# Altium schematic sheet Rev_C_Mcu.SchDoc
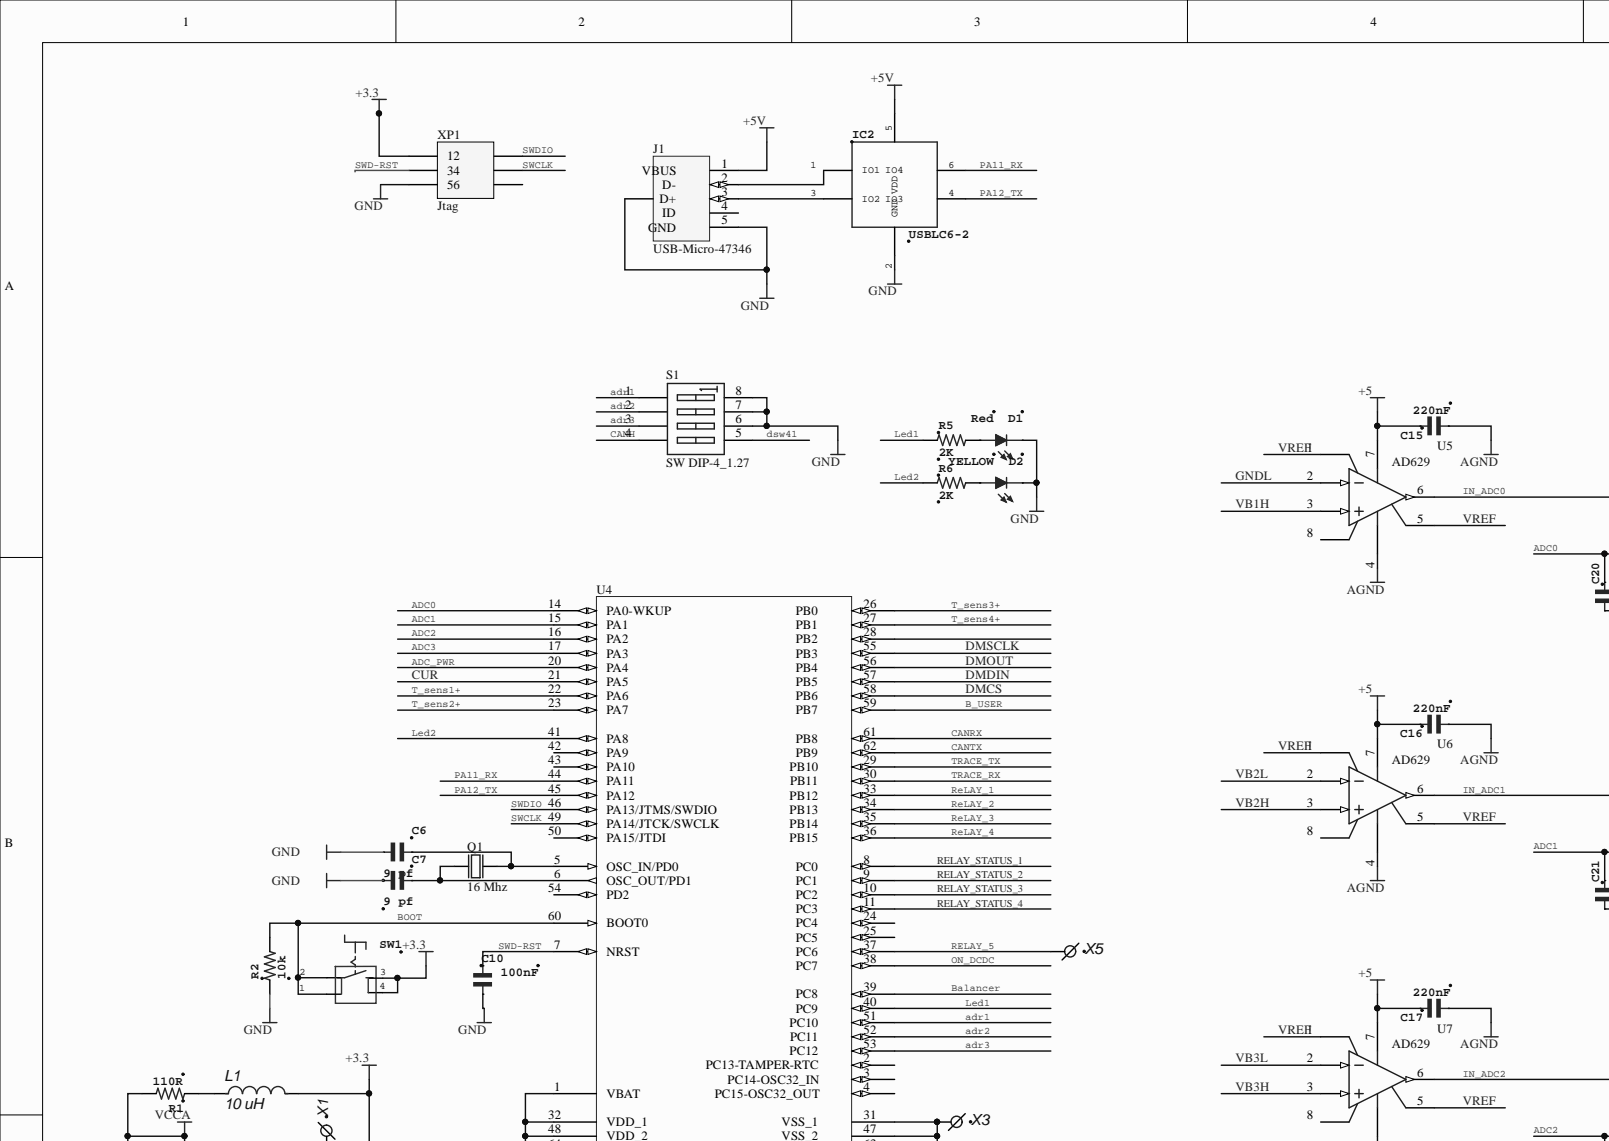
[diagram p0: Rev_C_Mcu.SchDoc, top left]
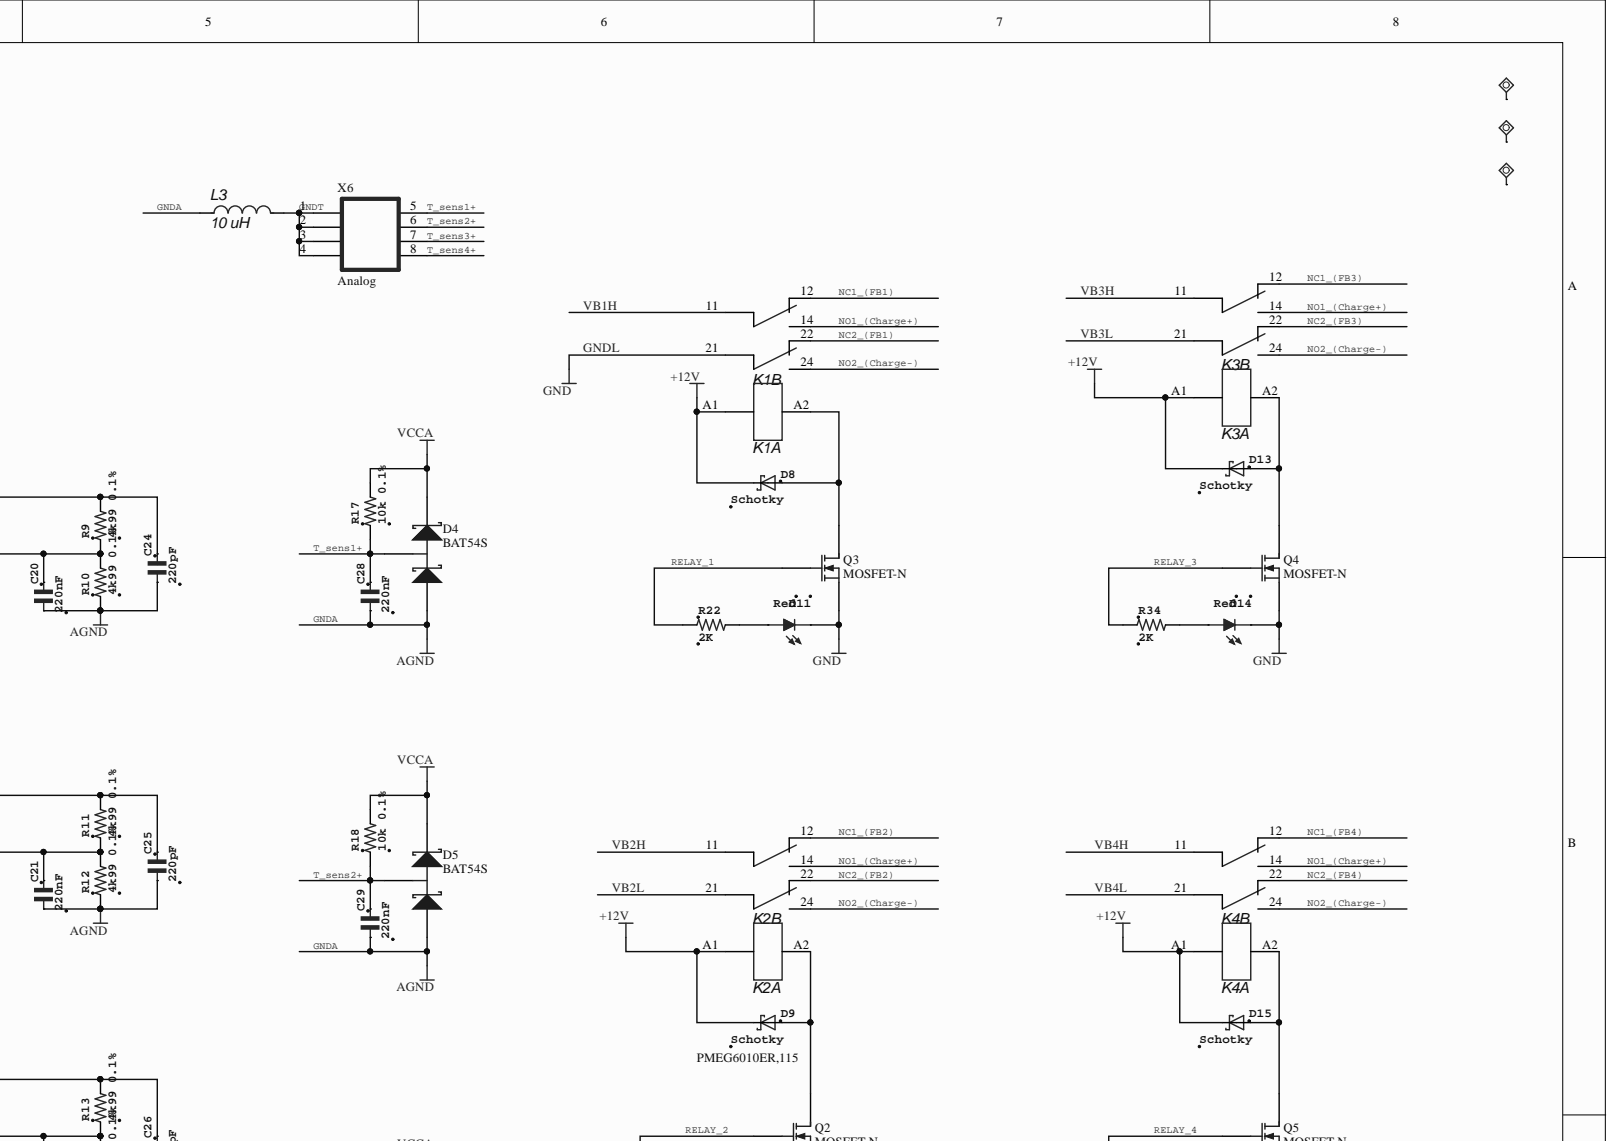
[diagram p1: Rev_C_Mcu.SchDoc, top right]
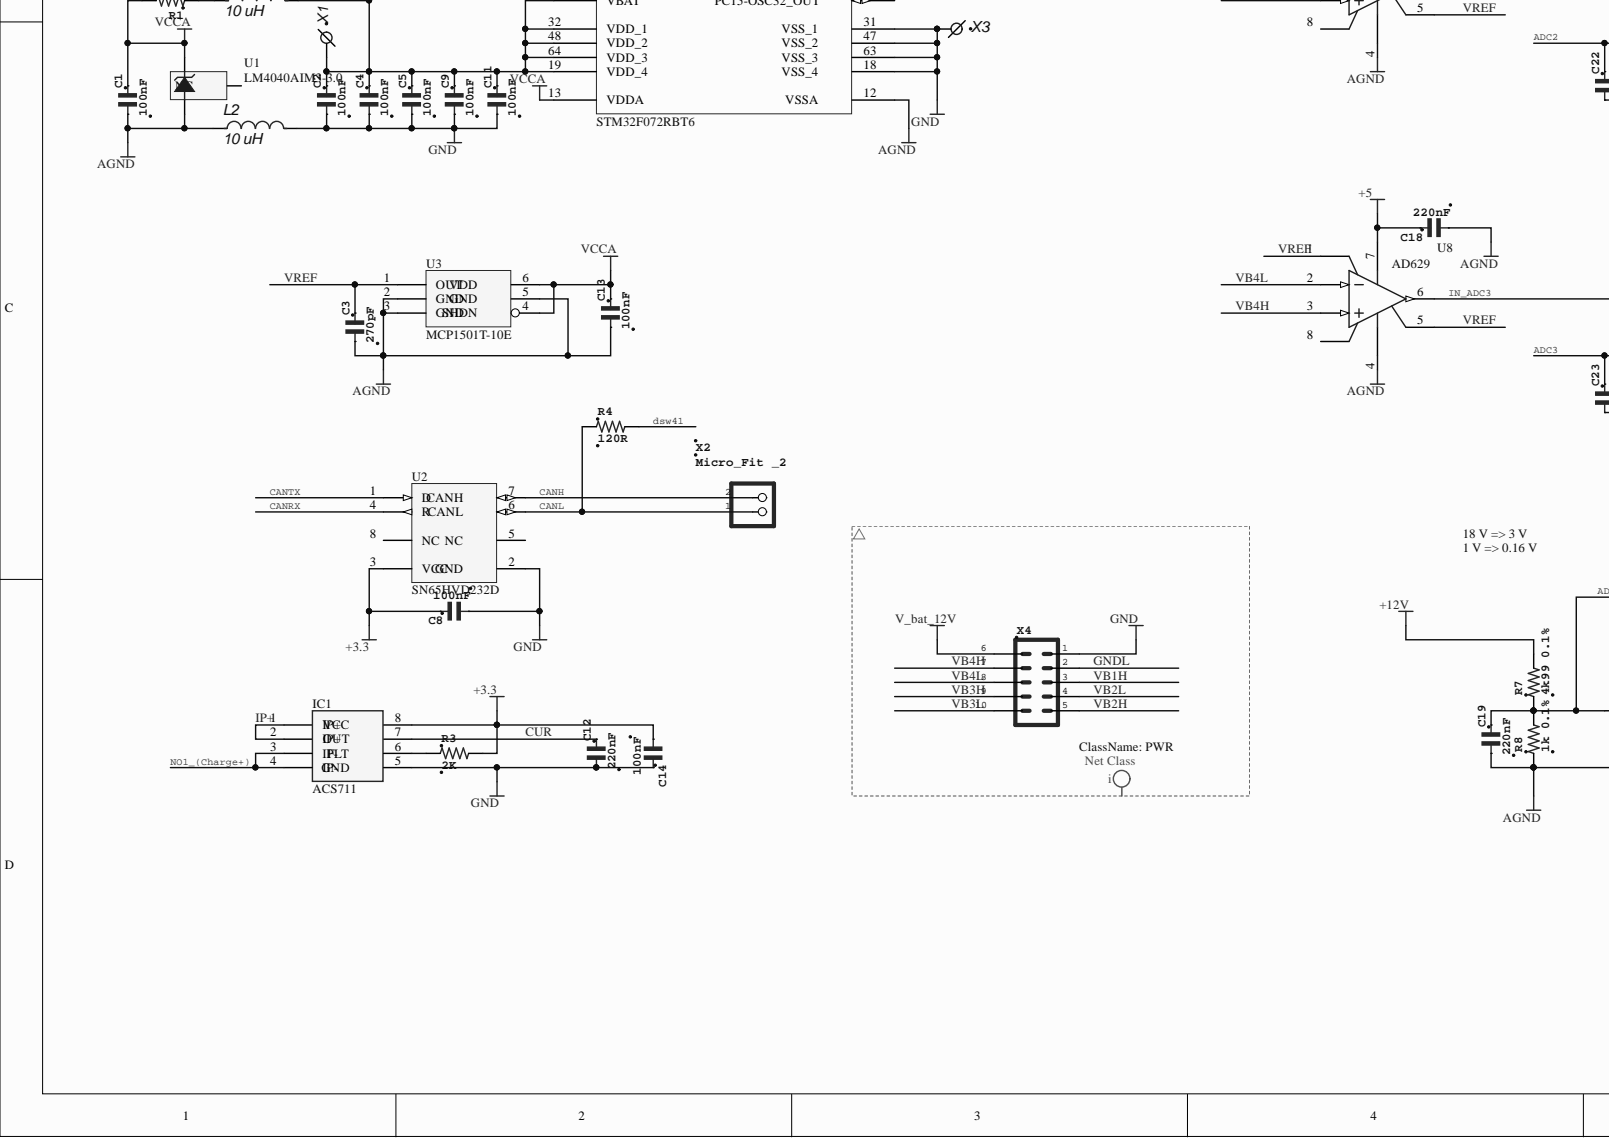
[diagram p2: Rev_C_Mcu.SchDoc, bottom left]
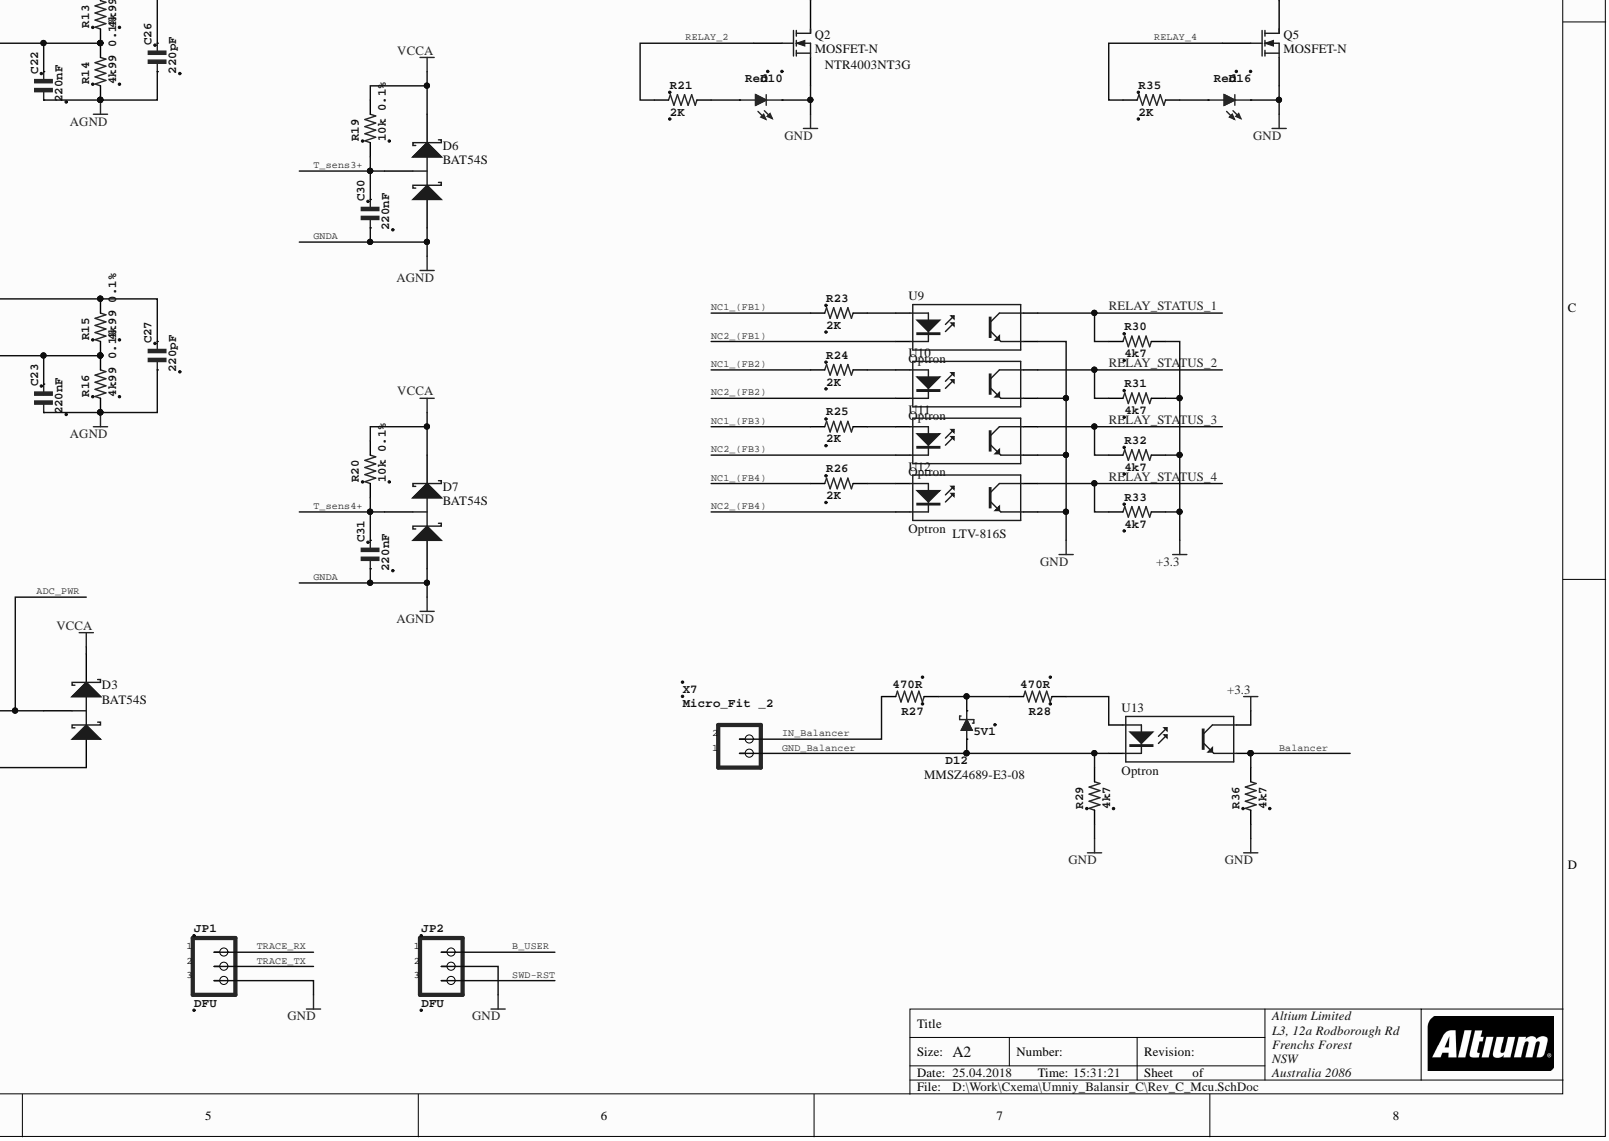
[diagram p3: Rev_C_Mcu.SchDoc, bottom right]

## Parts
C1  100nF  [C0603]
C2  100nF  [C0603]
C3  270pF  [C0603]
C4  100nF  [C0603]
C5  100nF  [C0603]
C6  9 pf  [C0603]
C7  9 pf  [C0603]
C8  100nF  [C0603]
C9  100nF  [C0603]
C10  100nF  [C0603]
C11  100nF  [C0603]
C12  220nF  [C0603]
C13  100nF  [C0603]
C14  100nF  [C0603]
C15  220nF  [C0603]
C16  220nF  [C0603]
C17  220nF  [C0603]
C18  220nF  [C0603]
C19  220nF  [C0603]
C20  220nF  [C0603]
C21  220nF  [C0603]
C22  220nF  [C0603]
C23  220nF  [C0603]
C24  220pF  [C0603]
C25  220pF  [C0603]
C26  220pF  [C0603]
C27  220pF  [C0603]
C28  220nF  [C0603]
C29  220nF  [C0603]
C30  220nF  [C0603]
C31  220nF  [C0603]
D1  Red  [CHIP-LED0603]
D2  YELLOW  [CHIP-LED0603]
D3  BAT54S  [SOT23_N]
D4  BAT54S  [SOT23_N]
D5  BAT54S  [SOT23_N]
D6  BAT54S  [SOT23_N]
D7  BAT54S  [SOT23_N]
D8  Schotky  [SOD123F]
D9  Schotky  Des: PMEG6010ER,115  [SOD123F]
D10  Red  [CHIP-LED0603]
D11  Red  [CHIP-LED0603]
D12  5V1  [425]
D13  Schotky  [SOD123F]
D14  Red  [CHIP-LED0603]
D15  Schotky  [SOD123F]
D16  Red  [CHIP-LED0603]
IC1  ACS711  [SO8-ACS]
IC2  USBLC6-2  [SOT23-6L]
J1  USB-Micro-47346  [USB_micro_B]
JP1  DFU  [1X03]
JP2  DFU  [1X03]
K1  Rele_RTE24012  [Switch_RTE24024]  (value not drawn)  (parts A,B)
K2  Rele_RTE24012  [Switch_RTE24024]  (value not drawn)  (parts A,B)
K3  Rele_RTE24012  [Switch_RTE24024]  (value not drawn)  (parts A,B)
K4  Rele_RTE24012  [Switch_RTE24024]  (value not drawn)  (parts A,B)
L1  10 uH  [C0603]
L2  10 uH  [C0603]
L3  10 uH  [C0603]
Q1  16 Mhz  [KX-7]
Q2  MOSFET-N  [SOT23-3N]
Q3  MOSFET-N  [SOT23-3N]
Q4  MOSFET-N  [SOT23-3N]
Q5  MOSFET-N  [SOT23-3N]
R1  110R  [R0603]
R2  10k  [R0603]
R3  2K  [R0603]
R4  120R  [R0603]
R5  2K  [R0603]
R6  2K  [R0603]
R7  4k99 0.1%  [R0603]
R8  1k 0.1%  [R0603]
R9  4k99 0.1%  [R0603]
R10  4k99 0.1%  [R0603]
R11  4k99 0.1%  [R0603]
R12  4k99 0.1%  [R0603]
R13  4k99 0.1%  [R0603]
R14  4k99 0.1%  [R0603]
R15  4k99 0.1%  [R0603]
R16  4k99 0.1%  [R0603]
R17  10k 0.1%  [R0603]
R18  10k 0.1%  [R0603]
R19  10k 0.1%  [R0603]
R20  10k 0.1%  [R0603]
R21  2K  [R0603]
R22  2K  [R0603]
R23  2K  [R0603]
R24  2K  [R0603]
R25  2K  [R0603]
R26  2K  [R0603]
R27  470R  [R0603]
R28  470R  [R0603]
R29  4k7  [R0603]
R30  4k7  [R0603]
R31  4k7  [R0603]
R32  4k7  [R0603]
R33  4k7  [R0603]
R34  2K  [R0603]
R35  2K  [R0603]
R36  4k7  [R0603]
S1  SW DIP-4_1.27  [218-4LPSTR]
SW1  PTS840 GKP SMTR LFS  [PTS840-P]  (value not drawn)
T1  TPP-SMD-15R  [SMD-15R]  (value not drawn)
T2  TPP-SMD-15R  [SMD-15R]  (value not drawn)
T3  TPP-SMD-15R  [SMD-15R]  (value not drawn)
U1  LM4040AIM3-3.0  [MF03A_N]
U2  SN65HVD232D  [PCA82C250]
U3  MCP1501T-10E  [SOT23-6L]
U4  STM32F072RBT6  [LQFP64_N]
U5  AD629  [ADI-R-8_N]
U6  AD629  [ADI-R-8_N]
U7  AD629  [ADI-R-8_N]
U8  AD629  [ADI-R-8_N]
U9  Optron  [LTV-816S]
U10  Optron  [LTV-816S]
U11  Optron  [LTV-816S]
U12  Optron  [LTV-816S]
U13  Optron  [LTV-816S]
X1  VDD  [Pin Header 1x1 TH Pitch 2.54mm]  (value not drawn)
X2  Micro_Fit _2  [43045-020X]
X3  GND  [Pin Header 1x1 TH Pitch 2.54mm]  (value not drawn)
X4  Mini-Fit 0039301101  [Mini-Fit 0039301101]  (value not drawn)
X5  RL5  [Pin Header 1x1 TH Pitch 2.54mm]  (value not drawn)
X6  Analog  [Micro_Fit_8]
X7  Micro_Fit _2  [43045-020X]
XP1  Jtag  [TC2030-IDC-NLFP]  (no BOM)

## Nets
+3.3: C2.2 C4.2 C5.2 C8.2 C9.2 C11.2 C14.1 IC1.8 L1.2 R3.2 R30.2 R31.2 R32.2 R33.2 SW1.3 SW1.4 U2.3(VCC) U4.1(VBAT) U4.19(VDD_4) U4.32(VDD_1) U4.48(VDD_2) U4.64(VDD_3) U13.4 X1.1 XP1.1
+5: C15.2 C16.2 C17.2 C18.2 U5.7 U6.7 U7.7 U8.7
+5V: IC2.5 J1.1
+12V: D8.C D9.C D13.C D15.C K1.A1 K2.A1 K3.A1 K4.A1 R7.2
V_BAT_12V: X4.6
VCCA: C1.2 C13.2 D3.2 D4.2 D5.2 D6.2 D7.2 R1.2 R17.2 R18.2 R19.2 R20.2 U1.1 U3.4 U3.6 U4.13(VDDA)
ADC0: C20.2 R9.1 R10.2 U4.14(PA0-WKUP)
ADC1: C21.2 R11.1 R12.2 U4.15(PA1)
ADC2: C22.2 R13.1 R14.2 U4.16(PA2)
ADC3: C23.2 R15.1 R16.2 U4.17(PA3)
ADC_PWR: C19.2 D3.3 R7.1 R8.2 U4.20(PA4)
ADR1: S1.1 U4.51
ADR2: S1.2 U4.52
ADR3: S1.3 U4.53
B_USER: JP2.1 U4.59
BALANCER: R36.2 U4.39 U13.3
BOOT: R2.2 SW1.1 SW1.2 U4.60(BOOT0)
CANH: S1.4 U2.7 X2.2
CANL: R4.1 U2.6 X2.1
CANRX: U2.4(R) U4.61
CANTX: U2.1(D) U4.62
CUR: C12.2 IC1.7 U4.21(PA5)
DMCS: U4.58
DMDIN: U4.57
DMOUT: U4.56
DMSCLK: U4.55
DSW41: R4.2 S1.5
GND_BALANCER: D12.2 R29.2 U13.2 X7.1
GNDA: C1.1 C3.1 C13.1 C15.1 C16.1 C17.1 C18.1 C19.1 C20.1 C21.1 C22.1 C23.1 C24.1 C25.1 C26.1 C27.1 C28.1 C29.1 C30.1 C31.1 D3.1 D4.1 D5.1 D6.1 D7.1 L2.1 L3.1 R8.1 R10.1 R12.1 R14.1 R16.1 U1.2 U3.2(GND) U3.3(GND) U3.5 U4.12 U5.4 U6.4 U7.4 U8.4
GNDL: C2.1 C4.1 C5.1 C6.2 C7.2 C8.1 C9.1 C10.1 C11.1 C12.1 C14.2 D1.C D2.C D10.C D11.C D14.C D16.C IC1.5 IC2.2(GND) J1.5 J1.SHIELD(D+) JP1.3 JP2.2 K1.21 L2.2 Q1.2 Q1.4 Q2.2 Q3.2 Q4.2 Q5.2 R2.1 R29.1 R36.1 S1.6 S1.7 S1.8 U2.2 U4.18 U4.31 U4.47 U4.63 U5.2 U9.3 U10.3 U11.3 U12.3 X3.1 X4.1 X4.2 XP1.5
GNDT: L3.2 X6.1 X6.2 X6.3 X6.4
IN_ADC0: C24.2 R9.2 U5.6
IN_ADC1: C25.2 R11.2 U6.6
IN_ADC2: C26.2 R13.2 U7.6
IN_ADC3: C27.2 R15.2 U8.6
IN_BALANCER: R27.2 X7.2
IP+: IC1.1(IP+) IC1.2(IP+)
LED1: R5.1 U4.40
LED2: R6.1 U4.41(PA8)
NC1_(FB1): K1.12 R23.1
NC1_(FB2): K2.12 R24.1
NC1_(FB3): K3.12 R25.1
NC1_(FB4): K4.12 R26.1
NC2_(FB1): K1.22 U9.2
NC2_(FB2): K2.22 U10.2
NC2_(FB3): K3.22 U11.2
NC2_(FB4): K4.22 U12.2
NO1_(CHARGE+): IC1.3(IP-) IC1.4(GND) K1.14 K2.14 K3.14 K4.14
NO2_(CHARGE-): K1.24 K2.24 K3.24 K4.24
ON_DCDC: U4.38
PA11_RX: IC2.6 U4.44(PA11)
PA12_TX: IC2.4 U4.45(PA12)
RELAY_1: Q3.1 R22.1 U4.33
RELAY_2: Q2.1 R21.1 U4.34
RELAY_3: Q4.1 R34.1 U4.35
RELAY_4: Q5.1 R35.1 U4.36
RELAY_5: U4.37 X5.1
RELAY_STATUS_1: R30.1 U4.8 U9.4
RELAY_STATUS_2: R31.1 U4.9 U10.4
RELAY_STATUS_3: R32.1 U4.10 U11.4
RELAY_STATUS_4: R33.1 U4.11 U12.4
SWCLK: U4.49(PA14/JTCK/SWCLK) XP1.4
SWD-RST: C10.2 JP2.3 U4.7(NRST) XP1.3
SWDIO: U4.46(PA13/JTMS/SWDIO) XP1.2
T_SENS1+: C28.2 D4.3 R17.1 U4.22(PA6) X6.5
T_SENS2+: C29.2 D5.3 R18.1 U4.23(PA7) X6.6
T_SENS3+: C30.2 D6.3 R19.1 U4.26 X6.7
T_SENS4+: C31.2 D7.3 R20.1 U4.27 X6.8
TRACE_RX: JP1.1 U4.30
TRACE_TX: JP1.2 U4.29
VB1H: K1.11 U5.3 X4.3
VB2H: K2.11 U6.3 X4.5
VB2L: K2.21 U6.2 X4.4
VB3H: K3.11 U7.3 X4.9
VB3L: K3.21 U7.2 X4.10
VB4H: K4.11 U8.3 X4.7
VB4L: K4.21 U8.2 X4.8
VREF: C3.2 U3.1(OUT) U5.1 U5.5 U6.1 U6.5 U7.1 U7.5 U8.1 U8.5
NetC6_1: C6.1 Q1.3 U4.5(OSC_IN/PD0)
NetC7_1: C7.1 Q1.1 U4.6(OSC_OUT/PD1)
NetD1_A: D1.A R5.2
NetD2_A: D2.A R6.2
NetD8_A: D8.A K1.A2 Q3.3
NetD9_A: D9.A K2.A2 Q2.3
NetD10_A: D10.A R21.2
NetD11_A: D11.A R22.2
NetD12_1: D12.1 R27.1 R28.2
NetD13_A: D13.A K3.A2 Q4.3
NetD14_A: D14.A R34.2
NetD15_A: D15.A K4.A2 Q5.3
NetD16_A: D16.A R35.2
NetIC1_6: IC1.6 R3.1
NetIC2_1: IC2.1(IO1) J1.2
NetIC2_3: IC2.3(IO2) J1.3
NetL1_1: L1.1 R1.1
NetR23_2: R23.2 U9.1
NetR24_2: R24.2 U10.1
NetR25_2: R25.2 U11.1
NetR26_2: R26.2 U12.1
NetR28_1: R28.1 U13.1
N00038:

Not connected: J1 4; T1 TP; T2 TP; T3 TP; U1 3; U2 5 8(NC); U4 2 3 4 24 25 28 42(PA9) 43(PA10) 50(PA15/JTDI) 54(PD2); U5 8; U6 8; U7 8; U8 8; XP1 6

## Library data of the parts
C1 C2 C4 C5 C8-C11 C13 C14 = C_0603: GRM188R71E104KA01J; MPN: GRM188F51E104ZA01D; MF: MURATA; Component Kind: Standard; OC_FARNELL: 1828902; Value: 100nF; Library Name: balan_1.SCHLIB
C3 = C_0603: CC0603KRX7R9BB271; MF: Yageo; Component Kind: Standard; MPN: CC0603KRX7R9BB271; Value: 270pF; Library Name: balan_1.SCHLIB
C6 C7 = C_0603: GRM1885C1H9R0DA01D; MF: MURATA; Component Kind: Standard; Value: 9 pf; Library Name: balan_1.SCHLIB
C12 C15-C23 C28-C31 = C_0603: TMK107B7224KA-T; MF: MURATA; Component Kind: Standard; Value: 220nF; Library Name: balan_1.SCHLIB
C24-C27 = C_0603: CC0603KRX7R9BB221; MF: Yageo; Component Kind: Standard; MPN: CC0603KRX7R9BB221; Value: 220pF; Library Name: balan_1.SCHLIB
D1 D10 D11 D14 D16 = LEDCHIP-LED0603_2: 150060RS75000; BLUE: 150060BS75000; GREEN: 150060VS75000; YELLOW: 150060YS75000; Component Kind: Standard; Library Name: ev-board_1.SchLib; Manufacturer Part Number: 150060RS75000; RED: 150060RS75000; Value: Red
D2 = LEDCHIP-LED0603_2: 150060YS75000; Manufacturer Part Number: 150060RS75000; BLUE: 150060BS75000; GREEN: 150060VS75000; Component Kind: Standard; Library Name: ev-board_1.SchLib; RED: 150060RS75000; Value: YELLOW; YELLOW: 150060YS75000
D3-D7 = BAT54S_1: TBAT54S,LM; Component Kind: Standard; ComponentLink1Description: Manufacturer Link; ComponentLink1URL: http://www.semiconductors.philips.com/; ComponentLink2Description: Datasheet; ComponentLink2URL: http://www.semiconductors.philips.com/acrobat/datasheets/BAT54_SERIES_4.pdf; DatasheetVersion: 4-Mar-2002; LatestRevisionDate: 12-Dec-2006; LatestRevisionNote: IPC-7351 Footprint Added.; Library Name: ev-board_1.SchLib; PackageDescription: 3-Pin SOT-23 Package 0.95 mm Pitch, 2.3 mm Lead Span; PackageReference: SOT23; PackageVersion: May-1999; Published: 17-Sep-2003; Publisher: Altium Limited
D8 D9 D13 D15 = SCHOTTKYSOD-123: PMEG4010ETR,115; PN: PMEG6010ER; Component Kind: Standard; Library Name: ev-board_1.SchLib
D12 = SCHOTTKY-1: MMSZ4689-E3-08; Component Kind: Standard; Des: MMSZ4689-E3-08; Library Name: ev-board_1.SchLib; Value: 5V1
IC1 = ACS711: ACS711ELCTR-12AB-T; Current: 12,5; Component Kind: Standard; Library Name: ev-board_1.SchLib; Manufacturer Part Number: ACS711ELCTR-12AB-T; Value: ACS711
IC2 = USBLC6-2: USBLC6-2SC6; Component Kind: Standard; Library Name: ev-board_1.SchLib; OC_FARNELL: unknown
J1 = USB-Micro-47346: 47346-0001; Component Kind: Standard; ComponentLink1Description: Manufacturer Link; ComponentLink1URL: http://www.tycoelectronics.com/; Library Name: Connectors.IntLib
JP1 JP2 = PINHD-1X3: pls; Component Kind: Standard; Library Name: ev-board_1.SchLib; OC_FARNELL: unknown
K1-K4 = Rele_RTE24012: RTE24012; Component Kind: Standard; Library Name: ev-board_1.SchLib
L1-L3 = L2: KLZ1608MHR100WTD25; group: Индуктивность; list: #NAME?; sheet: 10 uH tollerance; Component Kind: Standard; Library Name: Passive.IntLib; value: 10 uH
Q1 = XTAL_4-pin_grounded: TSX-3225 16.0000MF18X-AC3; Component Kind: Standard; Library Name: ev-board_1.SchLib
Q2-Q5 = MOSFET-N: NTR4003NT3G; Component Kind: Standard; LatestRevisionDate: 17-Jul-2002; LatestRevisionNote: Re-released for DXP Platform.; PackageDescription: TO, Flat Index; 3 In-Line, Axial Leads; Body Dia. 4.6mm; Lead Dia. 0.5 mm (max); PackageReference: E3; PackageVersion: 1995/1996; Published: 8-Jun-2000; Library Name: balan_1.SCHLIB; Publisher: Altium Limited
  Q2: Des: NTR4003NT3G
R1 = R_0603_18: RC0603FR-07110RL; Component Kind: Standard; Library Name: ev-board_1.SchLib; Manufacturer Part Number: RC0603FR-07110RL; OC_FARNELL: unknown; Value: 110R
R2 = R_0603_18: RC0603FR-0710KL; Component Kind: Standard; Library Name: ev-board_1.SchLib; Manufacturer Part Number: RC0603FR-0710KL; OC_FARNELL: unknown; Value: 10k
R3 R5 R6 R21-R26 R34 R35 = R_0603_18: RC0603FR-072KL; Component Kind: Standard; Library Name: ev-board_1.SchLib; Manufacturer Part Number: RC0603FR-072KL; OC_FARNELL: unknown; Value: 2K
R4 = R_0603_18: RC0603FR-07120RL; Component Kind: Standard; Library Name: ev-board_1.SchLib; Manufacturer Part Number: RC0603FR-07120RL; OC_FARNELL: unknown; Value: 120R
R7 R9-R16 = R_0603_18: ERA-3AEB4991V; Component Kind: Standard; Library Name: ev-board_1.SchLib; Manufacturer Part Number: ERA-3AEB4991V; OC_FARNELL: unknown; Value: 4k99 0.1%
R8 = R_0603_18: ERA-3AEB102V; Component Kind: Standard; Library Name: ev-board_1.SchLib; Manufacturer Part Number: ERA-3AEB102V; OC_FARNELL: unknown; Value: 1k 0.1%
R17-R20 = R_0603_18: ERA-3AEB103V; Component Kind: Standard; Library Name: ev-board_1.SchLib; Manufacturer Part Number: ERA-3AEB103V; OC_FARNELL: unknown; Value: 10k 0.1%
R27 R28 = R_0603_18: RC0603FR-07470RL; Component Kind: Standard; Library Name: ev-board_1.SchLib; Manufacturer Part Number: RC0603FR-07470RL; OC_FARNELL: unknown; Value: 470R
R29-R33 R36 = R_0603_18: RC0603FR-074K7L; Component Kind: Standard; Library Name: ev-board_1.SchLib; Manufacturer Part Number: RC0603FR-074K7L; OC_FARNELL: unknown; Value: 4k7
S1 = SW DIP-4_1.27: 218-4LPSTR; Code_IPC: SOIC127P600-8; Code_JEDEC: MS-012-AA; Component Kind: Standard; LatestRevisionDate: 29-May-2009; LatestRevisionNote: IPC-7351 Footprint Added.; Library Name: ev-board_1.SchLib; PackageDescription: 8-Pin Small Outline Integrated Circuit 1.27 mm Pitch; PackageReference: SO8; PackageVersion: Dec-2002; Published: 24-Mar-1999; Simulation: dpsw4; Publisher: Altium Limited
SW1 = PB_NOPTS840: Component Kind: Standard; Description: PTS840 GKP SMTR LFS; Library Name: ev-board_1.SchLib; Supplier 1: Digi-Key; Supplier 2: Mouser; Supplier Part Number 1: CKN10514CT-ND; Supplier Part Number 2: 611-PTS840GKPSMTR
T1-T3 = TPP-SMD-15R: tp; BOM: EXCLUDE; Component Kind: Standard; Library Name: ev-board_1.SchLib
U1 = LM4040AIM3-3.0: Manufacturer: National Semiconductor; CompType: Voltage Reference IC; PackageTopMarking: RKA*XTT; PowerWise: N; Component Kind: Standard; ComponentLink1Description: LM4040 Datasheet; ComponentLink1URL: http://www.national.com/ds/LM/LM4040.pdf; ComponentLink2Description: LM4040 Web Page; ComponentLink2URL: http://www.national.com/pf/LM/LM4040.html; ComponentLink3Description: MF03A Package Page; ComponentLink3URL: http://www.national.com/packaging/folders/mf03a.html; DatasheetVersion: Apr-2005; Description: LM4040AIM3-3.0; Library Name: NSC Voltage Reference.IntLib; PackageDescription: Molded SOT-23, .115 x .051 x .037in Body, 3 Lead, .075 in Pitch; PackageReference: MF03A; PackageVersion: revB, Mar-2003; PartNumber: LM4040AIM3-3.0; PinCount: 3; Published: 4-Dec-2008; RoHS: N; Publisher: Altium Limited
U2 = SN65HVD232D: Manufacturer: Texas Instruments; PartNumber: SN65HVD230D; Drivers Per Package: 1; Eco-Plan: Green (RoHS & no Sb/Br); ICC(Max)(mA): 17; Isolated: No; Low Power Mode: Standby; Rating: Catalog; SubFamily: CAN; Supply Voltage(s)(V): 3.3; Transient Pulse Protection(V): -25 to 25; Code_JEDEC: MS-012AA; Component Kind: Standard; ComponentLink1Description: Datasheet; ComponentLink1URL: http://www.ti.com/general/docs/lit/getliterature.tsp?genericPartNumber=SN65HVD230&fileType=pdf; DatasheetVersion: SLOS346K; Description: SN65HVD232D; Library Name: ev-board_1.SchLib; Mounting Technology: Surface Mount; PackageDescription: 8-Pin Plastic Small Outline Integrated Circuit, 4.9 x 3.9 mm, Pitch 1.27 mm Pitch; PackageReference: D8; PackageVersion: revM, Jun-2011; Receivers Per Package: 1; Supplier 1: Digi-Key; Supplier 2: Farnell; Supplier 3: Newark; Supplier 4: Mouser; Supplier Part Number 1: SN65HVD230D-ND; Supplier Part Number 2: 1103105; Supplier Part Number 3: 75C7744; Supplier Part Number 4: 595-SN65HVD230D
U3 = MCP1501T-10E: MCP1501T-10E/CHY; Code_IPC: SOT23-6; Component Kind: Standard; Library Name: ev-board_1.SchLib
U5-U8 = AD629: AD629ARZ; Component Kind: Standard; Generic Part Number: AD629ARZ; Library Name: ev-board_1.SchLib; PartNumber: AD629ARZ
U9-U13 = Optoisolator1: LTV-816S; Component Kind: Standard; DatasheetVersion: Nov-2003; LatestRevisionDate: 04-Mar-2005; LatestRevisionNote: Sch pins 3 & 4 Swapped.; Library Name: ev-board_1.SchLib; PackageReference: DIP-4; Published: 24-Mar-1999; Publisher: Altium Limited
  U12: Des: LTV-816S
X1 X3 X5 = Test Point: NC; Component Kind: Standard; Library Name: Mechanical.IntLib
  X1: list: VDD; sheet: VDD; value: VDD
  X3: list: GND; sheet: GND; value: GND
  X5: list: RL5; sheet: RL5; value: RL5
X2 X7 = 2PIN43045-020X: 0430450200; Component Kind: Standard; Library Name: ev-board_1.SchLib; Manufacturer Part Number: 0430450200
X4 = Mini-Fit 0039301101: 0039301101; Component Kind: Standard; Library Name: ev-board_1.SchLib
X6 = Micro_Fit_8: 0430450800; Component Kind: Standard; Library Name: ev-board_1.SchLib; Manufacturer Part Number: 0430450800
XP1 = TC2030-CTX-NL: Manufacturer: Tag-Connect LLC; Artikkelnr Noca: N.A.; Database Table Name: Connectors$; Datasheet: http://www.tag-connect.com/Materials/TC2030-IDC-NL.pdf; Description: TC2030-CTX-NL; LatestRevisionDate: 2013-08-08; Library Name: Nordic Passive DB.DbLib; Manufacturer Part Number: TC2030-CTX-NL; Part Number: TC2030-CTX-NL; Pin Count: 6; Published: 2013-08-08; Supplier: Tag-Connect; Supplier part number: TC2030-CTX-NL; Value: TC2030-CTX-NL; Publisher: Nordic Semiconductor
U4 = STM32F072RBT6: Component Kind: Standard; ComponentLink1Description: Manufacturer Link; ComponentLink1URL: www.st.com/stm32; Description: STM32F072RBT6; Library Name: ev-board_1.SchLib; PackageDescription: 64-Pin Low-Profile Quad Flat Pack, 10 x 10 mm Body, 0.5 mm Pitch (LQFP64); PackageReference: LQFP64; Publisher: Altium Limited
